# Altium schematic sheet 01_POWER_bat_IN.SchDoc
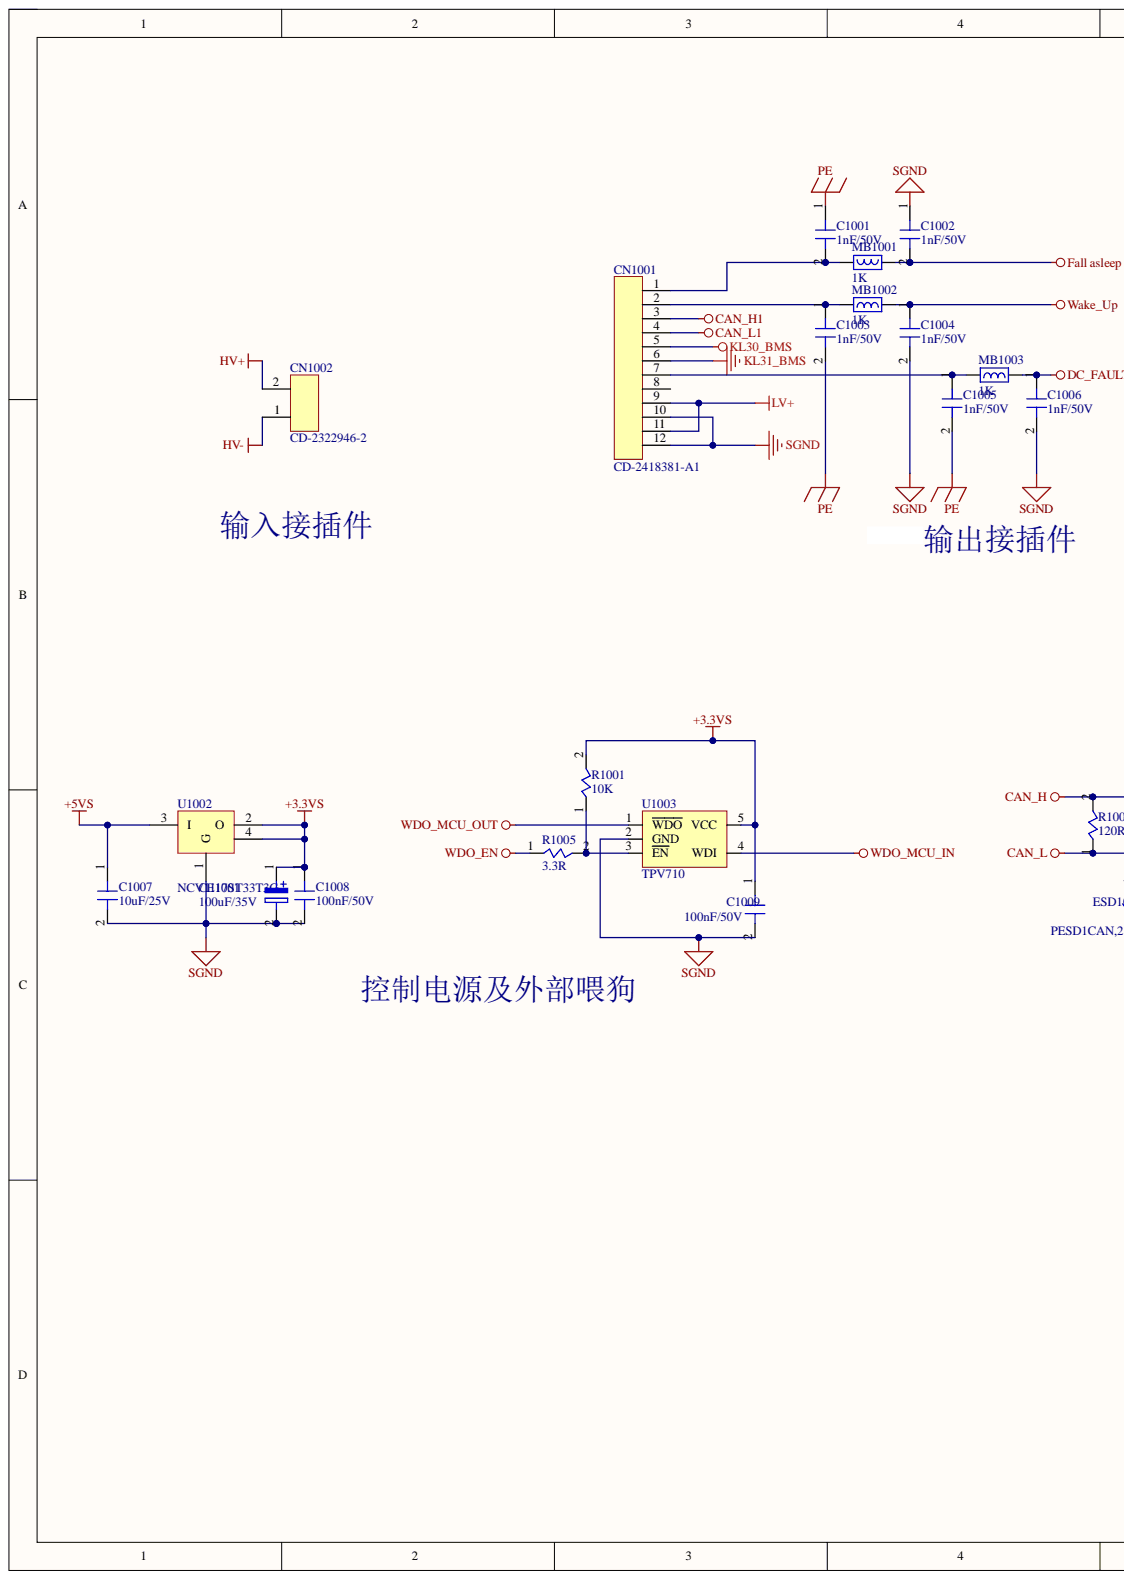
[diagram p0: 01_POWER_bat_IN.SchDoc, left]
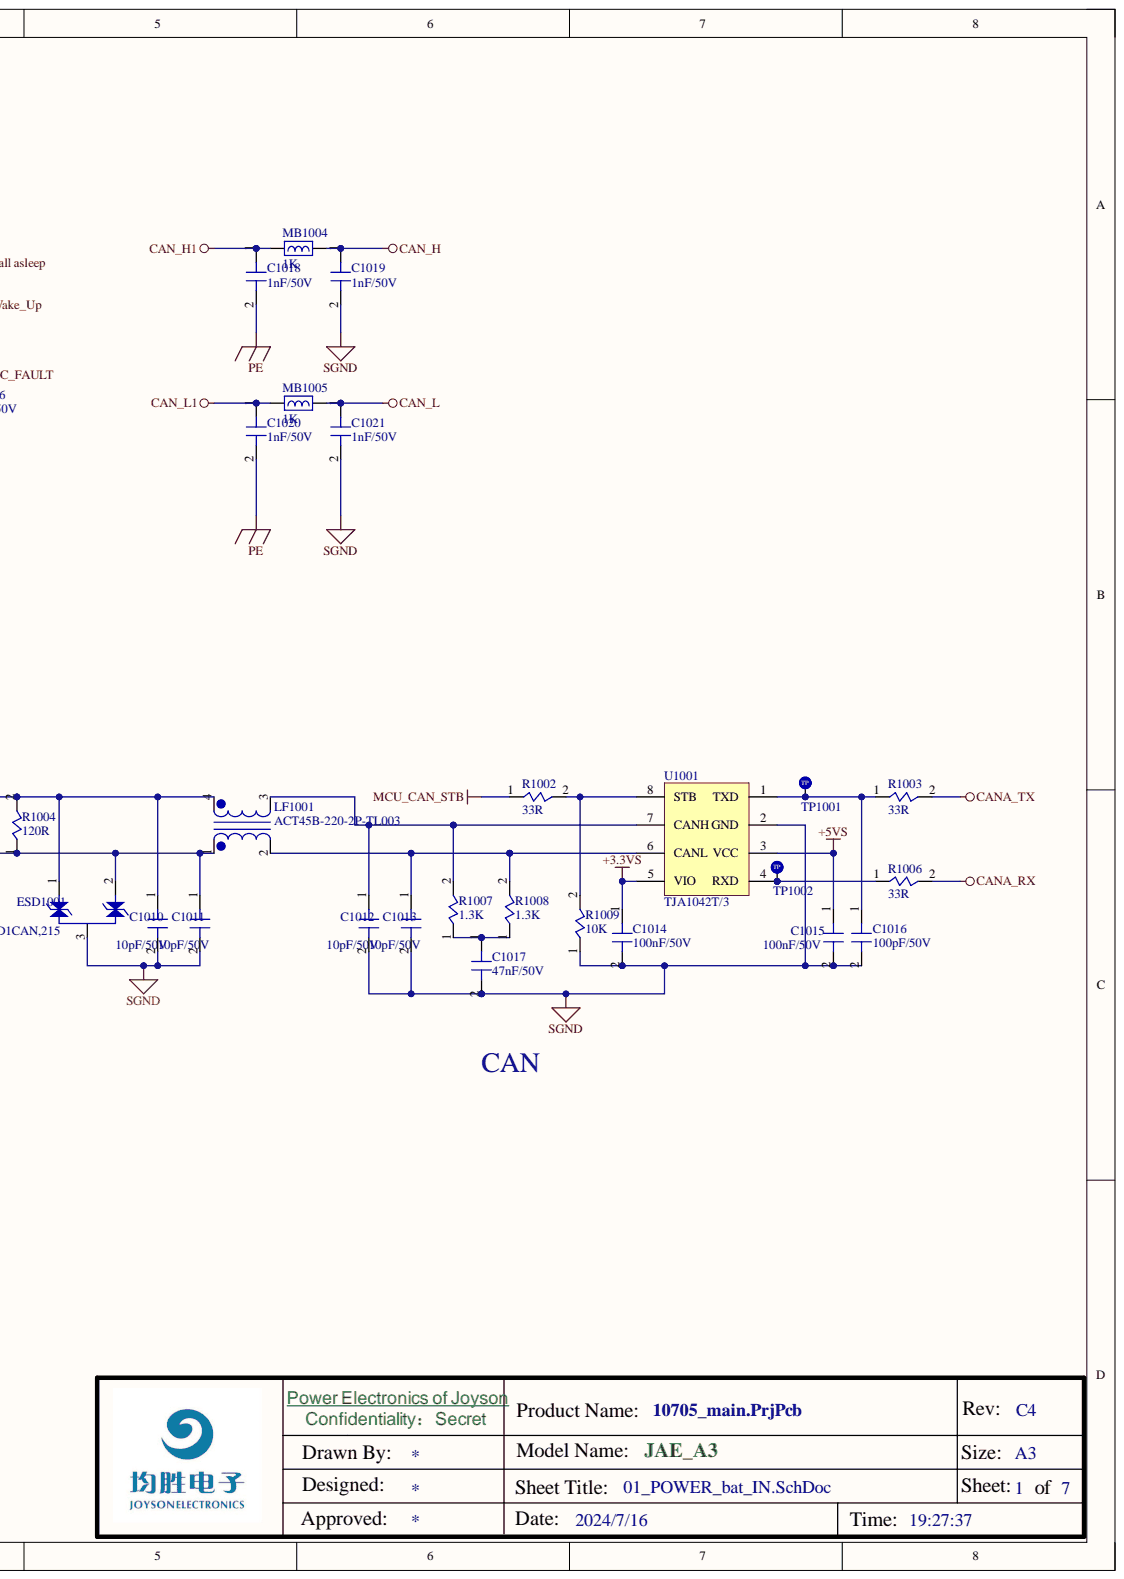
[diagram p1: 01_POWER_bat_IN.SchDoc, right]

## Parts
C1001  1nF  value 1nF/50V  [C-0603]
C1002  1nF  value 1nF/50V  [C-0603]
C1003  1nF  value 1nF/50V  [C-0603]
C1004  1nF  value 1nF/50V  [C-0603]
C1005  1nF  value 1nF/50V  [C-0603]
C1006  1nF  value 1nF/50V  [C-0603]
C1007  10uF  value 10uF/25V  [C-1206]
C1008  100nF  value 100nF/50V  [C-0603]
C1009  100nF  value 100nF/50V  [C-0402]
C1010  10pF  value 10pF/50V  [C-0402]
C1011  10pF  value 10pF/50V  [C-0402]
C1012  10pF  value 10pF/50V  [C-0402]
C1013  10pF  value 10pF/50V  [C-0402]
C1014  100nF  value 100nF/50V  [C-0603]
C1015  100nF  value 100nF/50V  [C-0603]
C1016  100pF  value 100pF/50V  [C-0603]
C1017  47nF  value 47nF/50V  [C-0603]
C1018  1nF  value 1nF/50V  [C-0603]
C1019  1nF  value 1nF/50V  [C-0603]
C1020  1nF  value 1nF/50V  [C-0603]
C1021  1nF  value 1nF/50V  [C-0603]
CE1001  100uF/35V  [CET-6.3*7.7]
CN1001  CD-2418381-A1  [CD-241838-A1]
CN1002  CD-2322946-2  [CD-2322946-2]
ESD1001  PESD1CAN,215  [SOT-23]
LF1001  ACT45B-220-2P-TL003  [LCM-4532]
MB1001  1K  [L-0805]
MB1002  1K  [L-0805]
MB1003  1K  [L-0805]
MB1004  1K  [L-0805]
MB1005  1K  [L-0805]
R1001  10K  [R-0402]
R1002  33R  [R-0402]
R1003  33R  [R-0402]
R1004  120R  [R-0603]
R1005  3.3R  [R-0805]
R1006  33R  [R-0402]
R1007  1.3K  [R-0603]
R1008  1.3K  [R-0603]
R1009  10K  [R-0402]
TP1001  TP1.0  [TP1.0]  (no BOM)
TP1002  TP1.0  [TP1.0]  (no BOM)
U1001  TJA1042T/3  [SOP-8]
U1002  NCV1117ST33T3G  [SOT-223]
U1003  TPV710  [SOT-23-5]

## Nets
+3.3VS: C1008.1 C1009.1 C1014.1 CE1001.1 R1001.2 U1001.5(VIO) U1002.2(O) U1002.4 U1003.5(VCC)
+5VS: C1007.1 C1015.1 U1001.3(VCC) U1002.3(I)
CAN_H: C1010.1 C1019.1 ESD1001.1 LF1001.4 MB1004.2 R1004.2
CAN_H1: C1018.1 CN1001.3 MB1004.1
CAN_L: C1011.1 C1021.1 ESD1001.2 LF1001.1 MB1005.2 R1004.1
CAN_L1: C1020.1 CN1001.4 MB1005.1
CANA_RX: R1006.2
CANA_TX: R1003.2
DC_FAULT: C1006.1 MB1003.2
Fall asleep: C1002.2 MB1001.1
HV+: CN1002.2
HV-: CN1002.1
KL30_BMS: CN1001.5
KL31_BMS: CN1001.6
LV+: CN1001.9 CN1001.11
MCU_CAN_STB: R1002.1
PE: C1001.1 C1003.2 C1005.2 C1018.2 C1020.2
SGND: C1002.1 C1004.2 C1006.2 C1007.2 C1008.2 C1009.2 C1010.2 C1011.2 C1012.2 C1013.2 C1014.2 C1015.2 C1016.2 C1017.2 C1019.2 C1021.2 CE1001.2 CN1001.10 CN1001.12 ESD1001.3 R1009.1 U1001.2(GND) U1002.1(G) U1003.2(GND)
Wake_Up: C1004.1 MB1002.2
WDO_EN: R1005.1
WDO_MCU_IN: U1003.4(WDI)
WDO_MCU_OUT: U1003.1(WDO)
NetC1001_2: C1001.2 CN1001.1 MB1001.2
NetC1003_1: C1003.1 CN1001.2 MB1002.1
NetC1005_1: C1005.1 CN1001.7 MB1003.1
NetC1012_1: C1012.1 LF1001.3 R1007.2 U1001.7(CANH)
NetC1013_1: C1013.1 LF1001.2 R1008.2 U1001.6(CANL)
NetC1016_1: C1016.1 R1003.1 TP1001.1 U1001.1(TXD)
NetC1017_1: C1017.1 R1007.1 R1008.1
NetR1001_1: R1001.1 R1005.2 U1003.3(EN)
NetR1002_2: R1002.2 R1009.2 U1001.8(STB)
NetR1006_1: R1006.1 TP1002.1 U1001.4(RXD)

Not connected: CN1001 8

## Library data of the parts
C1001-C1006 C1018-C1021 = 1101020014-00: 贴片电容封装; Brand: YAGEO; Database Table Name: Capacitor-SMD; Grade: 汽车级; Material Name: Capacitor; Spec/Modle: Capacitor-MLCC-0603-NPO-±5%-50V-1nF; Temprature: -55℃ to +125℃; Type: 贴片; Vendor No: AC0603JRNPO9BN102; Component Kind: Standard; Library Name: Database_Libs1.DbLib; Material No: 1101020014-00; Value2: 1nF
C1007 = 1101042015-00: 贴片电容封装; Brand: YAGEO; Database Table Name: Capacitor-SMD; Grade: 汽车级; Material Name: Capacitor; Spec/Modle: Capacitor-MLCC-1206-X7R-±10%-25V-10uF; Temprature: -55℃ to +125℃; Type: 贴片; Vendor No: AC1206KKX7R8BB106; Component Kind: Standard; Library Name: Database_Libs1.DbLib; Material No: 1101042015-00; Value2: 10uF
C1008 C1014 C1015 = 1101022028-00: 贴片电容封装; Brand: YAGEO; Database Table Name: Capacitor-SMD; Grade: 汽车级; Material Name: Capacitor; SAP Number: 05521-133/0000; Spec/Modle: Capacitor-MLCC-0603-X7R-±10%-50V-100nF; Temprature: -55℃ to +125℃; Type: 贴片; Vendor No: AC0603KRX7R9BB104; Component Kind: Standard; Library Name: Database_Libs1.DbLib; Material No: 1101022028-00; Value2: 100nF
C1009 = 1101012028-00: 贴片电容封装; Brand: YAGEO; Database Table Name: Capacitor-SMD; Grade: 汽车级; Material Name: Capacitor; SAP Number: 09701-715/0000; Spec/Modle: Capacitor-MLCC-0402-X7R-±10%-50V-100nF; Temprature: -55℃ to +125℃; Type: 贴片; Vendor No: AC0402KRX7R9BB104; Component Kind: Standard; Library Name: Database_Libs1.DbLib; Material No: 1101012028-00; Value2: 100nF
C1010-C1013 = 1101010001-00: 贴片电容封装; Brand: YAGEO; Database Table Name: Capacitor-SMD; Grade: 汽车级; Material Name: Capacitor; SAP Number: 09801-019/0000; Spec/Modle: Capacitor-MLCC-0402-NPO-±5%-50V-10pF; Temprature: -55℃ to +125℃; Type: 贴片; Vendor No: AC0402JRNPO9BN100; Component Kind: Standard; Library Name: Database_Libs1.DbLib; Material No: 1101010001-00; Value2: 10pF
C1016 = 1101020005-00: 贴片电容封装; Brand: YAGEO; Database Table Name: Capacitor-SMD; Grade: 汽车级; Material Name: Capacitor; Spec/Modle: Capacitor-MLCC-0603-NPO-±5%-50V-100pF; Temprature: -55℃ to +125℃; Type: 贴片; Vendor No: AC0603JRNPO9BN101; Component Kind: Standard; Library Name: Database_Libs1.DbLib; Material No: 1101020005-00; Value2: 100pF
C1017 = 1101022024-00: 贴片电容封装; Brand: YAGEO; Database Table Name: Capacitor-SMD; Grade: 汽车级; Material Name: Capacitor; SAP Number: 05521-242/0001; Spec/Modle: Capacitor-MLCC-0603-X7R-±10%-50V-47nF; Temprature: -55℃ to +125℃; Type: 贴片; Vendor No: AC0603KRX7R9BB473; Component Kind: Standard; Library Name: Database_Libs1.DbLib; Material No: 1101022024-00; Value2: 47nF
CE1001 = 1103052001-00: 铝电解电容封装; Brand: LELON; Database Table Name: Capacitor-ELE; ESR: 35mΩ; Grade: 工业级; Material Name: Capacitor; Ripple Current: 1700mA; SAP Number: 06005-521/0000; Spec/Modle: Capacitor-EC-HBO-35V-100uF-±20%-4000h-φ6.3*7.7-P2; Temprature: -55℃ to +125℃; Vendor No: HBO101M1VTR-0608; Component Kind: Standard; Library Name: Database_Libs1.DbLib; Material No: 1103052001-00; Type: 贴片; Value: 100uF/35V
CN1001 = 1611010006-00: 接插件封装; Brand: TE; Database Table Name: Connector; Grade: 工业级; Spec/Modle: Connector-Pin Header-P4/3-90°-32VDC-3*4pin; Temprature: -40℃ to +125℃; Component Kind: Standard; Library Name: Database_Libs1.DbLib; Material Name: Connector; Material No: 1611010006-00; Type: 插件; Value: CD-2418381-A1; Vendor No: CD-2418381-A1
CN1002 = 1603311001-00: 接插件封装; Brand: TE; Database Table Name: Connector; Grade: 工业级; Spec/Modle: Connector-Pin Header-P6.35-90°-1000VDC-1A-2pin; Temprature: -40℃ to +125℃; Component Kind: Standard; Library Name: Database_Libs1.DbLib; Material Name: Connector; Material No: 1603311001-00; Type: 插件; Value: CD-2322946-2; Vendor No: CD-2322946-2
ESD1001 = 1209060001-00: ESD-SOT23封装; Brand: nexperia; Database Table Name: Diode; Grade: 工业级; SAP Number: 05508-996/0000; Spec/Modle: Diode-ESD-SOT23-200W-27.8V-3A-25.4V to 30.3V-mark(AN); Temprature: -65℃ to +150℃; Component Kind: Standard; Library Name: Database_Libs1.DbLib; Material Name: Diode; Material No: 1209060001-00; Type: 贴片; Value: PESD1CAN,215; Vendor No: PESD1CAN,215
LF1001 = 1504010003-00: 共模电感SMD封装; Brand: TDK; Database Table Name: Magnetic; Grade: 工业级; Material Name: Inductor; Spec/Modle: Inductor-CMC-4532-+50/-30%-22uH-0.2A-1.0Ω; Temprature: -40℃ to +150℃; Component Kind: Standard; Library Name: Database_Libs1.DbLib; Material No: 1504010003-00; Type: 贴片; Value: ACT45B-220-2P-TL003; Vendor No: ACT45B-220-2P-TL003
MB1001-MB1005 = 1503011001-00: 磁珠; Brand: 风华; Database Table Name: Magnetic; Grade: 工业级; Material Name: Inductor; Spec/Modle: Inductor-Magnetic bead-0805-±25%-1kΩ-1A-0.25Ω; Temprature: -55℃ to +85℃; Vendor No: CBW201209U102T; Component Kind: Standard; Library Name: Database_Libs1.DbLib; Material No: 1503011001-00; Type: 贴片; Value: 1K
R1001 R1009 = 1001010457-00: 贴片电阻封装; Brand: YAGEO; Database Table Name: Resistor-SMD; Grade: 汽车级; Material Name: Resistor; SAP Number: 05544-477/0000; Spec/Modle: Resistor-SMD-0402-1/16W-±1%-±100ppm/℃-10KΩ; Temprature: -55℃ to +155℃; Vendor No: AC0402FR-0710KL; Component Kind: Standard; Library Name: Database_Libs1.DbLib; Material No: 1001010457-00; Type: 贴片; Value: 10K
R1002 R1003 R1006 = 1001010172-00: 贴片电阻封装; Brand: YAGEO; Database Table Name: Resistor-SMD; Grade: 汽车级; Material Name: Resistor; SAP Number: 05544-192/0000; Spec/Modle: Resistor-SMD-0402-1/16W-±1%-±100ppm/℃-33Ω; Temprature: -55℃ to +155℃; Vendor No: AC0402FR-0733RL; Component Kind: Standard; Library Name: Database_Libs1.DbLib; Material No: 1001010172-00; Type: 贴片; Value: 33R
R1004 = 1001020237-00: 贴片电阻封装; Brand: YAGEO; Database Table Name: Resistor-SMD; Grade: 汽车级; Material Name: Resistor; SAP Number: 05543-257/0000; Spec/Modle: Resistor-SMD-0603-1/10W-±1%-±100ppm/℃-120Ω; Temprature: -55℃ to +155℃; Vendor No: AC0603FR-07120RL; Component Kind: Standard; Library Name: Database_Libs1.DbLib; Material No: 1001020237-00; Type: 贴片; Value: 120R
R1005 = 1001030058-00: 贴片电阻封装; Brand: YAGEO; Database Table Name: Resistor-SMD; Grade: 汽车级; Material Name: Resistor; SAP Number: 05542-078/0000; Spec/Modle: Resistor-SMD-0805-1/8W-±1%-±200ppm/℃-3.3Ω; Temprature: -55℃ to +155℃; Vendor No: AC0805FR-073R3L; Component Kind: Standard; Library Name: Database_Libs1.DbLib; Material No: 1001030058-00; Type: 贴片; Value: 3.3R
R1007 R1008 = 1001020355-00: 贴片电阻封装; Brand: YAGEO; Database Table Name: Resistor-SMD; Grade: 汽车级; Material Name: Resistor; SAP Number: 05543-375/0000; Spec/Modle: Resistor-SMD-0603-1/10W-±1%-±100ppm/℃-1.3KΩ; Temprature: -55℃ to +155℃; Vendor No: AC0603FR-071K3L; Component Kind: Standard; Library Name: Database_Libs1.DbLib; Material No: 1001020355-00; Type: 贴片; Value: 1.3K
TP1001 TP1002 = TP: Library Name: my lib.schlib
U1001 = TJA1042T: Brand: NXP; Material Name: IC; Material No: 2065000020-00; SAP Number: 06005-454/0000; Spec/Modle: SMD,IC,CAN Transceiver,SOP-8; Component Kind: Standard; Library Name: my lib.schlib; Vendor No: TJA1042T/3
U1002 = 1402010004-00: 芯片，LDO; Brand: onsemi; Database Table Name: IC; Grade: 汽车级; Spec/Modle: IC-LDO-SOT223-3.3V-1.0%-1A-VCC(20V)-mark(AYW1733V); Temprature: -40℃ to +125℃; Component Kind: Standard; Library Name: Database_Libs1.DbLib; Material Name: IC; Material No: 1402010004-00; Type: 贴片; Value: NCV1117ST33T3G; Vendor No: NCV1117ST33T3G
U1003 = 1412010004-00: 芯片，看门狗芯片; Brand: 3PEAK; Database Table Name: IC; Grade: 工业级; Spec/Modle: IC-Watchdog-SOT23-5-VCC(1.67->5.5V); Temprature: -40℃ to +125℃; Component Kind: Standard; Library Name: Database_Libs1.DbLib; Material Name: IC; Material No: 1412010004-00; Type: 贴片; Value: TPV710; Vendor No: TPV710
